# Altium schematic sheet Fonte.SchDoc
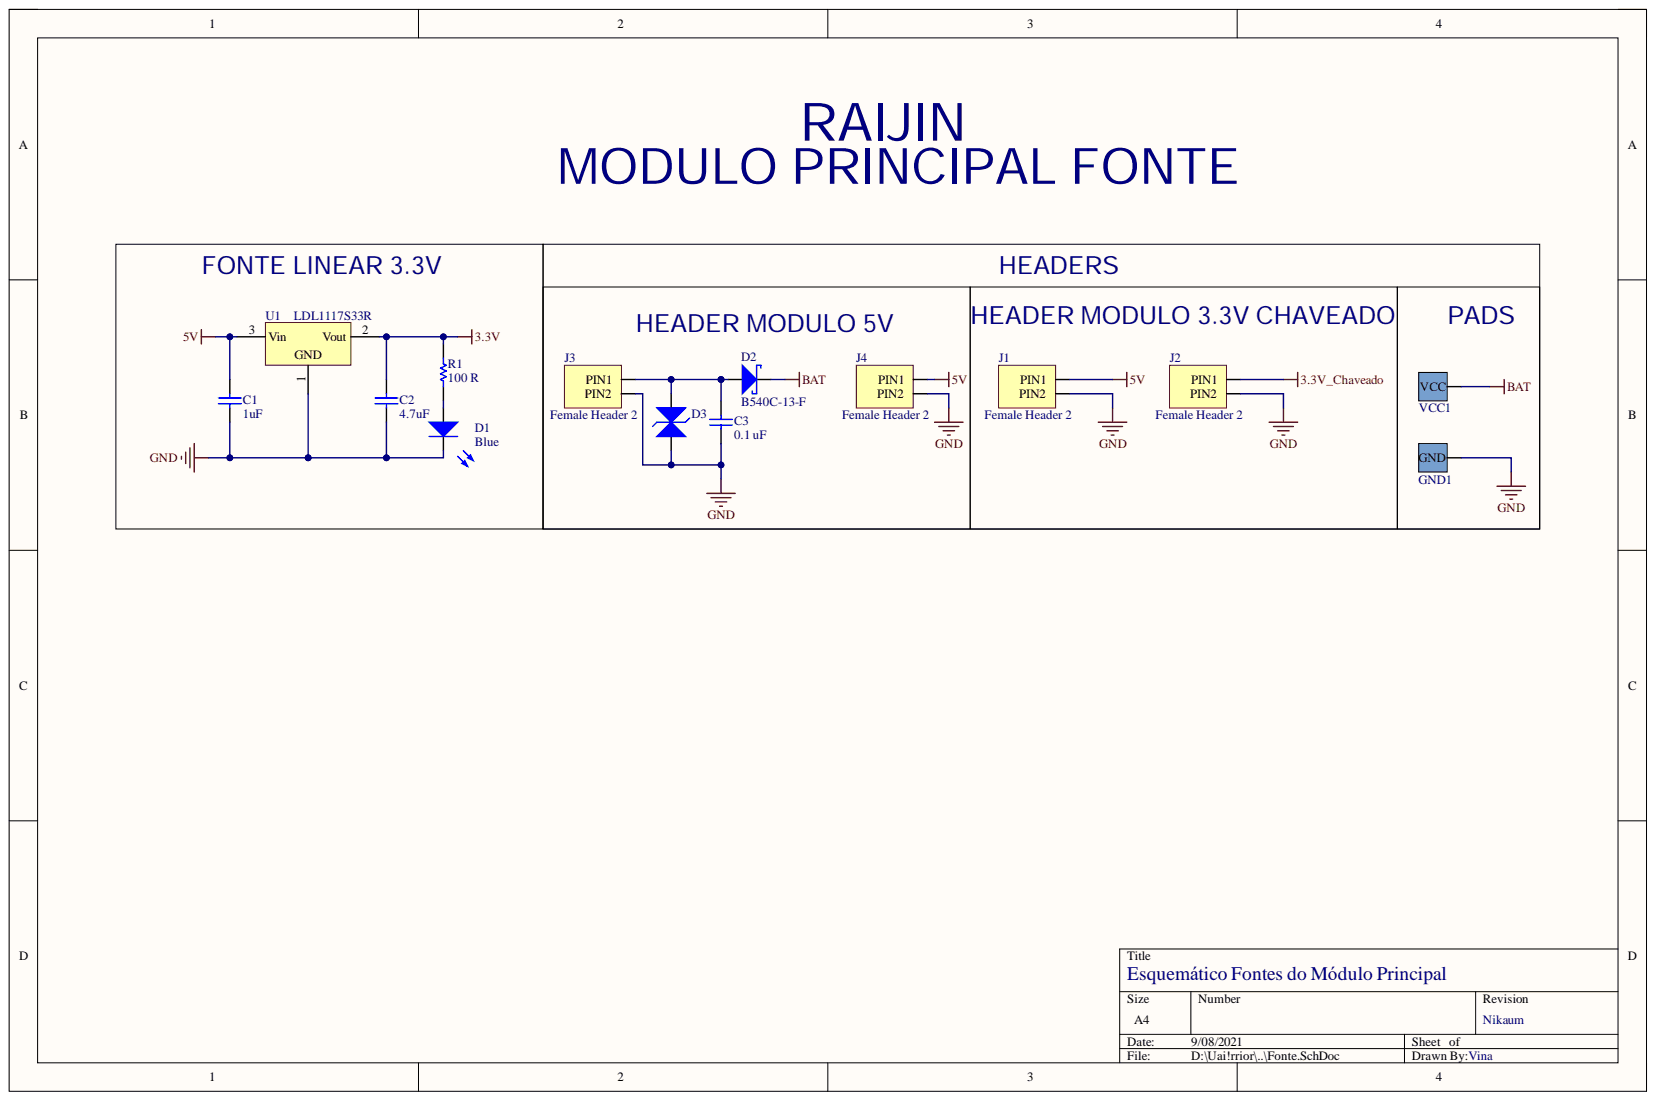
[diagram p0: Fonte.SchDoc]

## Parts
C1  1uF  [C0805]
C2  4.7uF  [C0805]
C3  0.1 uF  [C0805]
D1  Blue  [DIODO_2-SMD-3215]
D2  B540C-13-F  [DIODO_DO-214AB]
D3  ESD110B102ELE6327XTMA1  [DIODO-TSSLP-2-4]  (value not drawn)
GND1  Ground Pad  (value not drawn)
J1  Female Header 2  [Female Header 2]
J2  Female Header 2  [Female Header 2]
J3  Female Header 2  [Female Header 2]
J4  Female Header 2  [Female Header 2]
R1  100 R  [RESISTOR_0603]
U1  LDL1117S33R  [SOT-223-4]
VCC1  Source Pad  (value not drawn)

## Nets
3.3V: C2.2 R1.2 U1.2
3.3V_Chaveado: J2.PIN1
5V: C1.2 J1.PIN1 J4.PIN1 U1.3
BAT: D2.C VCC1.A
GND: C1.1 C2.1 C3.2 D1.C D3.A GND1.A J1.PIN2 J2.PIN2 J3.PIN2 J4.PIN2 U1.1
NetC3_1: C3.1 D2.A D3.C J3.PIN1
NetD1_A: D1.A R1.1

## Library data of the parts
C1 = 885012107015: CAP CER 1uF 25V X5R 0805; Manufacturer: Wurth Electronik; Library Control - Checked Footprint: Yes; Library Control - Person that Checked Footprint: Nikaum; Tolerance: 20%; Category: Ceramic Capacitor; Component Kind: Standard; ComponentLink1Description: Datasheet; ComponentLink1URL: https://br.mouser.com/datasheet/2/445/885012107015-1727441.pdf; ComponentLink2Description: Mouser Link; ComponentLink2URL: https://br.mouser.com/ProductDetail/Wurth-Elektronik/885012107015?qs=%2Fha2pyFaduggjUC9vDyrNMYsuF9m0S%2FHKLBl4FOBDDHl%252B%252B2XqSGtSQ%3D%3D; Dieletric: X5R; ESR: -; Library Control - Checked Schematic: Yes; Library Control - Last Update: 28/06/2021 00:10; Library Ref: Capacitor; Operating Temperature: -55 C ~ 85 C; Package / Case: 0805 (2012 Metric); Part Number: 885012107015; Price: 1 = 0,10, 10=0,082, 100=0,073 (USD); Size / Dimension: 2,00 L x 1,25 W x 1,25 H; Supplier 1: Mouser; Supplier Part Number 1: 710-885012107015; Value: 1uF; Voltage - Rated: 25V; Library Control - Person that Checked Schematic: Nikaum; Library Control - Person that did the Last Update Footprint: Nikaum; Library Control - Person that did the Last Update Schematic: Nikaum; Library Name: Uai!Tecas.IntLib
C2 = 0805X475M250CT: CAP CER 4.7uF 25V 20% 0805; Manufacturer: Walsin; Dieletric: X5R; Library Control - Checked Footprint: No; Library Control - Checked Schematic: Yes; Library Control - Person that Checked Schematic: Guilherme; Library Control - Person that did the Last Update Schematic: Marcos; Category: Ceramic Capacitor; Component Kind: Standard; ComponentLink1Description: Datasheet; ComponentLink1URL: https://br.mouser.com/datasheet/2/210/WTC_MLCC_General_Purpose-1534899.pdf; ComponentLink2Description: Mouser Link; ComponentLink2URL: https://br.mouser.com/ProductDetail/Walsin/0805X475M250CT?qs=%2Fha2pyFadugovbtJ4IPO2aI5%2FHfN6czWOLxzJ368rfolfgZFQZPK8JJR9MicoCMy; ESR: -; Library Control - Last Update: 26/06/2021 19:59; Library Ref: Capacitor; Operating Temperature: -55°C ~ 85°C; Package / Case: 0805(2012 metric); Part Number: 0805X475M250CT; Price: 1=0,26 10=0,178 100=0,101 1000=0,064 (USD); Size / Dimension: 2 mm L x 1.25 mm W x 0.8 mm H; Supplier 1: Mouser; Supplier Part Number 1: 791-0805X475M250CT; Tolerance: 20%; Value: 4.7uF; Voltage - Rated: 25V; Library Name: Uai!Tecas.IntLib
C3 = C0805C104K5RACTU: CAP CER 0.1uF 50V X7R 0805; Manufacturer: Kemet; Library Control - Checked Footprint: No; Library Control - Checked Schematic: Yes; Library Control - Person that Checked Schematic: Guilherme; Library Control - Person that did the Last Update Schematic: Marcos; Tolerance: 10%; Voltage - Rated: 50 VDC; Category: Ceramic Capacitor; Component Kind: Standard; ComponentLink1Description: Datasheet; ComponentLink1URL: https://br.mouser.com/datasheet/2/212/KEM_C1002_X7R_SMD-1102033.pdf; ComponentLink2Description: Mouser Link; ComponentLink2URL: https://br.mouser.com/ProductDetail/KEMET/C0805C104K5RACTU?qs=Pc30aiB8zWUISNb2Qeyxfw%3D%3D; Dieletric: X7R; ESR: -; Library Control - Last Update: 26/06/2021 20:48; Library Ref: Capacitor; Operating Temperature: -55°C ~ 125°C; Package / Case: 0805(2012 metric); Part Number: C0805C104K5RACTU; Price: 1=0,24 10=0,126 100=0,076 1000=0,059 (USD); Size / Dimension: 2 mm L  x 1.25 mm W  x  0.78 mm H; Supplier 1: Mouser; Supplier Part Number 1: 80-C0805C104K5R; Value: 0.1 uF; Library Name: Uai!Tecas.IntLib
D1 = EAST3215BA5: LED 75mW BLUE 45MCD 3215; Manufacturer: Everlight Electronics; Current - Forward (If): 20 mA; Library Control - Checked Footprint: yes; Library Control - Person that Checked Footprint: Lucas; Library Control - Person that did the Last Update Footprint: Stéfany (Ster); Reverse Voltage: 5 V; Viewing Angle: 130°; Voltage - Forward (Vf): 3,30 V; Wavelength: 468 nm; Category: Standard LEDs - SMD; Color: Blue; Component Kind: Standard; ComponentLink1Description: Mouser Link; ComponentLink1URL: https://www.mouser.com/ProductDetail/Everlight/EAST3215BA5?qs=Cqqh%252BS766wnzp9nQVj5A4g==; ComponentLink2Description: Datasheet; ComponentLink2URL: https://www.mouser.com/datasheet/2/143/EAST3215BA5-1154292.pdf; Library Control - Checked Schematic: yes; Library Control - Last Update: 13/06/2021 12:45; Library Name: Diodo.SchLib; Library Ref: Diodo/Led; Luminous Intensity: 45 mcd; Max Current Rating: 20 mA; Max Power Dissipation: 75 mW; Operating Temperature: - 40 °C ~ 85 °C; Package / Case: 3215; Part Number: EAST3215BA5; Price: 1 = 0,3800, 10 = 0,2050, 100 = 0.1080 (USD); Supplier 1: Mouser; Supplier Part Number 1: 638-EAST3215BA5; Library Control - Person that Checked Schematic: Lucas; Library Control - Person that did the Last Update Schematic: Stéfany (Ster)
D2 = B540C-13-F: DIODE SCHOTTKY 40V 5A DO-214AB; Manufacturer: Diodes Incorporated; Capacitance @ Vr, F: 300 pF @ 4V, 1MHz; Current - Reverse Leakage @ Vr: 500 uA; Diode Type: Schottky Barrier Rectifier; Library Control - Checked Footprint: yes; Library Control - Person that did the Last Update Footprint: Dalarme; Library Control - Person that did the Last Update Schematic: Stéfany(Ster); Max Forward Surge Current (Ifsm): 100 A; Voltage - Forward (Vf) (Max) @ If: 550 mV; Category: Schottky Diodes & Rectifiers; Component Kind: Standard; ComponentLink1Description: Mouser Link; ComponentLink1URL: https://www.mouser.com/ProductDetail/Diodes-Incorporated/B540C-13-F?qs=%2Fha2pyFadugB25bXQPJfFXI5hzpNpnVpraRSN9VwgHc%3D; ComponentLink2Description: Datasheet; ComponentLink2URL: https://www.mouser.com/datasheet/2/115/ds13012-73423.pdf; Current - Average Rectified (Io): 5 A; Library Control - Checked Schematic: yes; Library Control - Last Update: 21/06/2021 13:20; Library Ref: Diode; Operating Temperature: - 55ºC ~ 125ºC; Package / Case: SMC (DO-214AB); Part Number: B540C-13-F; Price: 1 = 0,53, 10 = 0,454, 100 = 0,339 (USD); Supplier 1: Mouser; Supplier Part Number 1: 621-B540C-F; Voltage - DC Reverse (Vr) (Max): 40 V; Library Name: Uai!Tecas.IntLib
D3 = ESD110B102ELE6327XTMA1: DIODE TVS 26V 14.2A DO-214AA-2; Manufacturer: Infineon; Library Control - Checked Footprint: No; Library Control - Person that did the Last Update Footprint: Nikaum; Power Dissipation: 58 W; Voltage - Forward (Vf) (Max) @ If: -15 V - +15 V; Category: ESD Suppressors / TVS Diodes; Component Kind: Standard; ComponentLink1Description: Datasheet; ComponentLink1URL: https://www.mouser.com/datasheet/2/196/Infineon-ESD110-B1-02series-DS-v01_04-EN-1731333.pdf; ComponentLink2Description: Mouser Link; ComponentLink2URL: https://www.mouser.com/ProductDetail/Infineon-Technologies/ESD110B102ELE6327XTMA1?qs=%2Fha2pyFaduiogV8KDD5PH1He7eBI5EnoxEmNaeUv4GVgUCwgbnNdrWufEfAgVCzv; Diode Type: TVS; Library Control - Checked Schematic: No; Library Control - Last Update: 13/06/2021 13:28; Library Ref: Diode; Operating Temperature: - 40ºC ~ 125ºC; Package / Case: TSLP-2; Part Number: ESD110B102ELE6327XTMA1; Price: 1 = 0,48, 10 = 0,36, 100 = 0,225 (USD); Supplier 1: Mouser; Supplier Part Number 1: 726-ESD110B102ELE632; Library Control - Person that did the Last Update Schematic: Nikaum; Library Name: Uai!Tecas.IntLib
GND1 = Ground Pad Sumô 3Kg: GND PAD SUMÔ 3KG; Cable AWG: 12 (AWG); Component Kind: Standard; Library Ref: Pads; Recomended Category: Sumô 3Kg; Size: 5 x 2 (mm); Library Name: Uai!Tecas.IntLib
J1-J4 = Female Header 2: System: Connector; Component Kind: Standard; Library Name: Uai!Tecas.IntLib
R1 = RC0603FR-10100RL: RES SMD 100 OHM 1% 1/10W 0603; Manufacturer: Yageo; Library Control - Checked Footprint: Yes; Library Control - Person that Checked Footprint: Nikaum; Library Control - Person that did the Last Update Footprint: Nicolas; Power Rating: 100mW (1/10W); Temperature Coefficient: 100 PPM / C; Voltage Rating: 75 V; Category: Thick Film Resistors - SMD; Component Kind: Standard; ComponentLink1Description: Mouser Link; ComponentLink1URL: https://br.mouser.com/ProductDetail/Yageo/RC0603FR-10100RL/?qs=EiqXWrxQq62RG9T9SdQg0g==; ComponentLink2Description: Datasheet Link; ComponentLink2URL: https://br.mouser.com/datasheet/2/447/Yageo_03_18_2021_PYu_RC_Group_51_RoHS_L_11-2199992.pdf; Library Control - Checked Schematic: Yes; Library Control - Last Update: 27/06/2021 21:11; Library Ref: Resistor; Operating Temperature: - 55 C~155 C; Package / Case: 0603 (1608 metric); Part Number: RC0603FR-10100RL; Price: 1 = 0,10, 10 = 0,018, 100 = 0,006 (USD); Resistor Type: Fixo; Size / Dimension: 1,60 L x 0,80 W x 0,45 H; Supplier 1: Mouser; Supplier Part Number 1: 603-RC0603FR-10100RL; Tolerance: 1 %; Value: 100 R; Library Control - Person that Checked Schematic: Nikaum; Library Control - Person that did the Last Update Schematic: Nikaum; Library Name: Uai!Tecas.IntLib
U1 = LDL1117S33R: IC REG LINEAR 3.3V 1.2A SOT-223-4; Manufacturer: STMicroelectronics; Current - Quiescent (Iq): 250 uA; Library Control - Checked Footprint: Yes; Library Control - Person that Checked Footprint: Nícolas; Library Control - Person that did the Last Update Footprint: Pierre; Max Power Dissipation: Internally limited; Number of Regulators: 1; Output Type: Fixed; Voltage - Dropout (Max): 600mV (1.2 A); Voltage - Input (Max): 18V; Voltage - Output (Max): 3.6V; Category: Linear Voltage Regulator; Component Kind: Standard; ComponentLink1Description: Mouser Link; ComponentLink1URL: https://br.mouser.com/ProductDetail/STMicroelectronics/LDL1117S33R?qs=%2Fha2pyFadugA91l4ky%2FRZ5SN3GrMJ6YI2MUHTqmRDLfkBVrFdA%252BW6Q%3D%3D; ComponentLink2Description: Datasheet; ComponentLink2URL: https://br.mouser.com/datasheet/2/389/dm00366442-1799212.pdf; Current - Output: 1.2 A; Library Control - Checked Schematic: Yes; Library Control - Last Update: 23/06/21 10:22; Library Ref: Voltage Regulator; Operating Temperature: -40°C~125°C; Package/ Case: SOT-223-4; Part Number: LDL1117S33R; Power Dissipation: Internally limited; Price: 1= 0.46000, 10= 0.34000, 100= 0.22900 (USD); Supplier 1: Mouser; Supplier Part Number 1: 511-LDL1117S33R; Voltage - Output (Min/Fixed): 3.3 V; Library Control - Person that Checked Schematic: Nícolas; Library Control - Person that did the Last Update Schematic: Nícolas; Library Name: Uai!Tecas.IntLib
VCC1 = Source Pad Sumô 3Kg: VCC PAD SUMÔ 3KG; Cable AWG: 12 (AWG); Component Kind: Standard; Library Ref: Pads; Recomended Category: Sumô 3Kg; Size: 5 x 2 (mm); Library Name: Uai!Tecas.IntLib
